# Altium schematic sheet Morpho_Arduino.SchDoc
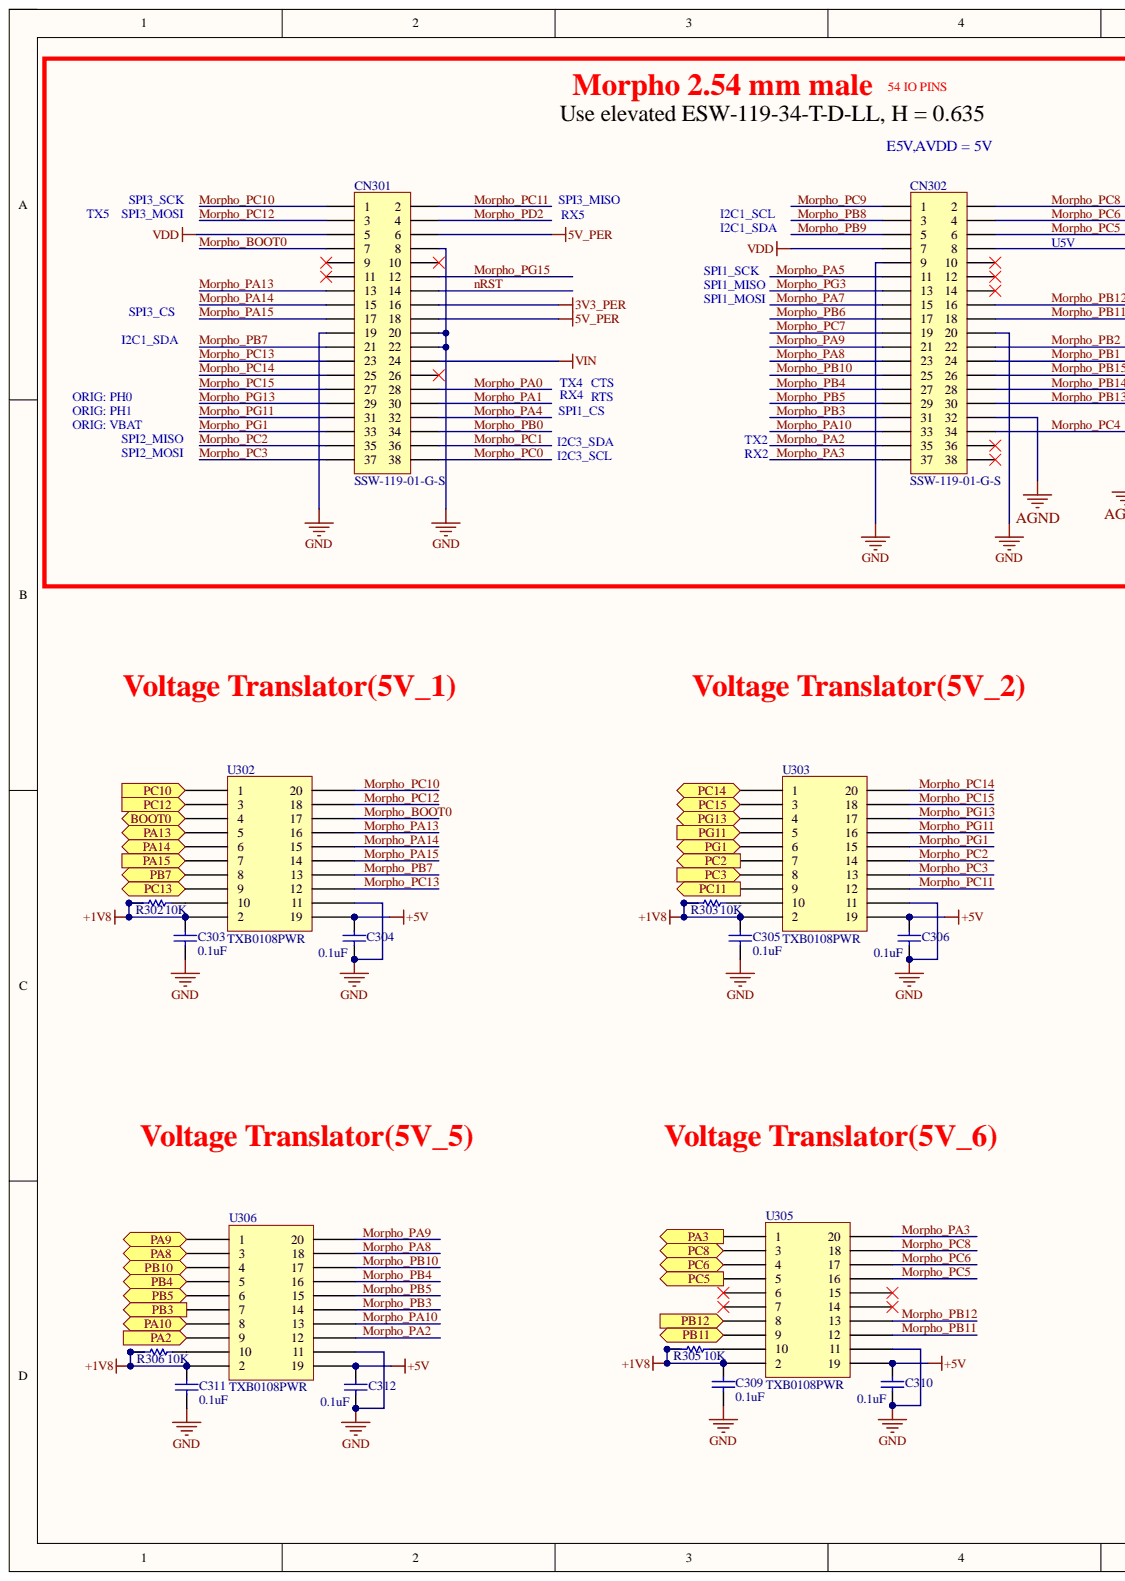
[diagram p0: Morpho_Arduino.SchDoc, left]
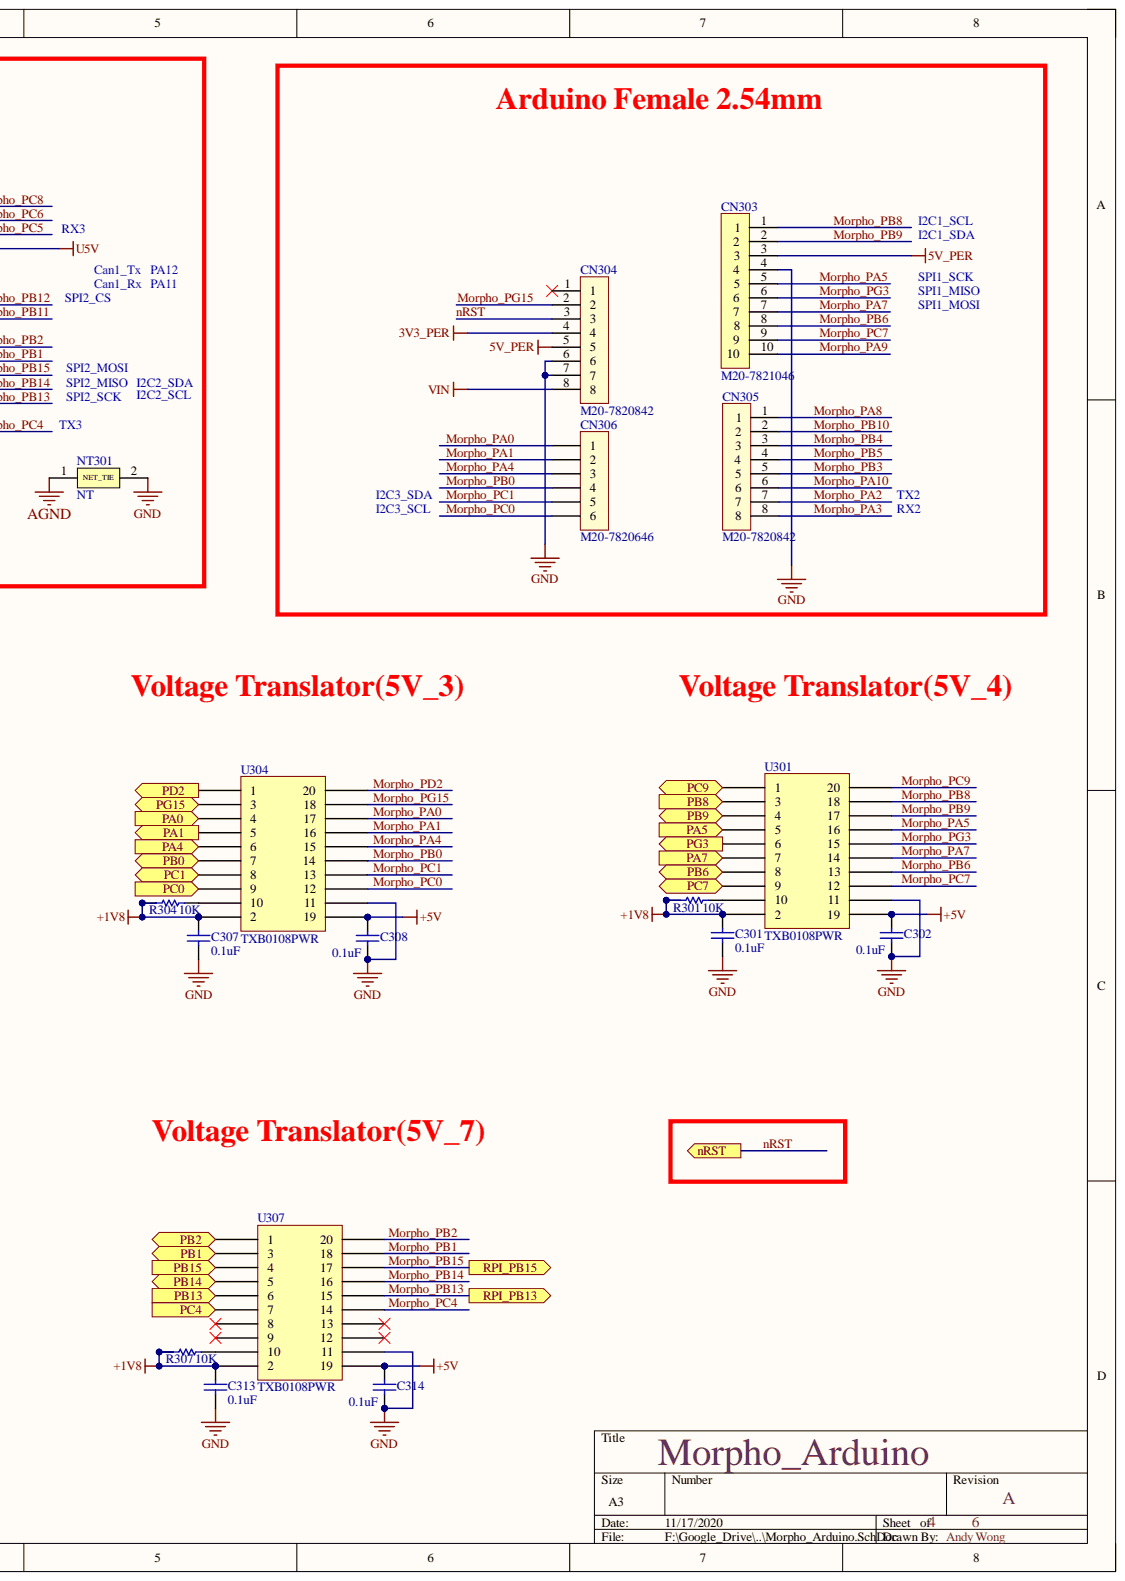
[diagram p1: Morpho_Arduino.SchDoc, right]

## Parts
C301  0.1uF  [Cap 0402]
C302  0.1uF  [Cap 0402]
C303  0.1uF  [Cap 0402]
C304  0.1uF  [Cap 0402]
C305  0.1uF  [Cap 0402]
C306  0.1uF  [Cap 0402]
C307  0.1uF  [Cap 0402]
C308  0.1uF  [Cap 0402]
C309  0.1uF  [Cap 0402]
C310  0.1uF  [Cap 0402]
C311  0.1uF  [Cap 0402]
C312  0.1uF  [Cap 0402]
C313  0.1uF  [Cap 0402]
C314  0.1uF  [Cap 0402]
CN301  SSW-119-01-G-S  [TSW-119-09-T-D]
CN302  SSW-119-01-G-S  [TSW-119-09-T-D]
CN303  M20-7821046  [M20-7821046]
CN304  M20-7820842  [M20-7820842]
CN305  M20-7820842  [M20-7820842]
CN306  M20-7820646  [M20-7820646]
NT301  NT  [NetTie]
R301  10K  [Res 0402]
R302  10K  [Res 0402]
R303  10K  [Res 0402]
R304  10K  [Res 0402]
R305  10K  [Res 0402]
R306  10K  [Res 0402]
R307  10K  [Res 0402]
U301  TXB0108PWR  [TXB0108PWR]
U302  TXB0108PWR  [TXB0108PWR]
U303  TXB0108PWR  [TXB0108PWR]
U304  TXB0108PWR  [TXB0108PWR]
U305  TXB0108PWR  [TXB0108PWR]
U306  TXB0108PWR  [TXB0108PWR]
U307  TXB0108PWR  [TXB0108PWR]

## Ports
BOOT0, nRST, PA0, PA1, PA2, PA3, PA4, PA5, PA7, PA8, PA9, PA10, PA13, PA14, PA15, PB0, PB1, PB2, PB3, PB4, PB5, PB6, PB7, PB8, PB9, PB10, PB11, PB12, PB13, PB14, PB15, PC0, PC1, PC2, PC3, PC4, PC5, PC6, PC7, PC8, PC9, PC10, PC11, PC12, PC13, PC14, PC15, PD2, PG1, PG3, PG11, PG13, PG15, RPI_PB13, RPI_PB15

## Nets
3V3_PER: CN301.16 CN304.4
5V_PER: C302.2 C304.2 C306.2 C308.2 C310.2 C312.2 C314.2 CN301.6 CN301.18 CN303.3 CN304.5 U301.19 U302.19 U303.19 U304.19 U305.19 U306.19 U307.19
+1V8: C301.1 C303.1 C305.1 C307.1 C309.1 C311.1 C313.1 R301.2 R302.2 R303.2 R304.2 R305.2 R306.2 R307.2 U301.2 U302.2 U303.2 U304.2 U305.2 U306.2 U307.2
AGND: CN302.32 NT301.1
GND: C301.2 C302.1 C303.2 C304.1 C305.2 C306.1 C307.2 C308.1 C309.2 C310.1 C311.2 C312.1 C313.2 C314.1 CN301.8 CN301.19 CN301.20 CN301.22 CN302.9 CN302.20 CN303.4 CN304.6 CN304.7 NT301.2 U301.11 U302.11 U303.11 U304.11 U305.11 U306.11 U307.11
U5V: CN302.8
VDD: CN301.5 CN302.7
VIN: CN301.24 CN304.8
BOOT0: U302.4 ; port BOOT0
Morpho_BOOT0: CN301.7 U302.17
Morpho_PA0: CN301.28 CN306.1 U304.17
Morpho_PA1: CN301.30 CN306.2 U304.16
Morpho_PA2: CN302.35 CN305.7 U306.12
Morpho_PA3: CN302.37 CN305.8 U305.20
Morpho_PA4: CN301.32 CN306.3 U304.15
Morpho_PA5: CN302.11 CN303.5 U301.16
Morpho_PA7: CN302.15 CN303.7 U301.14
Morpho_PA8: CN302.23 CN305.1 U306.18
Morpho_PA9: CN302.21 CN303.10 U306.20
Morpho_PA10: CN302.33 CN305.6 U306.13
Morpho_PA13: CN301.13 U302.16
Morpho_PA14: CN301.15 U302.15
Morpho_PA15: CN301.17 U302.14
Morpho_PB0: CN301.34 CN306.4 U304.14
Morpho_PB1: CN302.24 U307.18
Morpho_PB2: CN302.22 U307.20
Morpho_PB3: CN302.31 CN305.5 U306.14
Morpho_PB4: CN302.27 CN305.3 U306.16
Morpho_PB5: CN302.29 CN305.4 U306.15
Morpho_PB6: CN302.17 CN303.8 U301.13
Morpho_PB7: CN301.21 U302.13
Morpho_PB8: CN302.3 CN303.1 U301.18
Morpho_PB9: CN302.5 CN303.2 U301.17
Morpho_PB10: CN302.25 CN305.2 U306.17
Morpho_PB11: CN302.18 U305.12
Morpho_PB12: CN302.16 U305.13
Morpho_PB13: CN302.30 U307.15 ; port RPI_PB13
Morpho_PB14: CN302.28 U307.16
Morpho_PB15: CN302.26 U307.17 ; port RPI_PB15
Morpho_PC0: CN301.38 CN306.6 U304.12
Morpho_PC1: CN301.36 CN306.5 U304.13
Morpho_PC2: CN301.35 U303.14
Morpho_PC3: CN301.37 U303.13
Morpho_PC4: CN302.34 U307.14
Morpho_PC5: CN302.6 U305.16
Morpho_PC6: CN302.4 U305.17
Morpho_PC7: CN302.19 CN303.9 U301.12
Morpho_PC8: CN302.2 U305.18
Morpho_PC9: CN302.1 U301.20
Morpho_PC10: CN301.1 U302.20
Morpho_PC11: CN301.2 U303.12
Morpho_PC12: CN301.3 U302.18
Morpho_PC13: CN301.23 U302.12
Morpho_PC14: CN301.25 U303.20
Morpho_PC15: CN301.27 U303.18
Morpho_PD2: CN301.4 U304.20
Morpho_PG1: CN301.33 U303.15
Morpho_PG3: CN302.13 CN303.6 U301.15
Morpho_PG11: CN301.31 U303.16
Morpho_PG13: CN301.29 U303.17
Morpho_PG15: CN301.12 CN304.2 U304.18
nRST: CN301.14 CN304.3 ; port nRST
PA0: U304.4 ; port PA0
PA1: U304.5 ; port PA1
PA2: U306.9 ; port PA2
PA3: U305.1 ; port PA3
PA4: U304.6 ; port PA4
PA5: U301.5 ; port PA5
PA7: U301.7 ; port PA7
PA8: U306.3 ; port PA8
PA9: U306.1 ; port PA9
PA10: U306.8 ; port PA10
PA13: U302.5 ; port PA13
PA14: U302.6 ; port PA14
PA15: U302.7 ; port PA15
PB0: U304.7 ; port PB0
PB1: U307.3 ; port PB1
PB2: U307.1 ; port PB2
PB3: U306.7 ; port PB3
PB4: U306.5 ; port PB4
PB5: U306.6 ; port PB5
PB6: U301.8 ; port PB6
PB7: U302.8 ; port PB7
PB8: U301.3 ; port PB8
PB9: U301.4 ; port PB9
PB10: U306.4 ; port PB10
PB11: U305.9 ; port PB11
PB12: U305.8 ; port PB12
PB13: U307.6 ; port PB13
PB14: U307.5 ; port PB14
PB15: U307.4 ; port PB15
PC0: U304.9 ; port PC0
PC1: U304.8 ; port PC1
PC2: U303.7 ; port PC2
PC3: U303.8 ; port PC3
PC4: U307.7 ; port PC4
PC5: U305.5 ; port PC5
PC6: U305.4 ; port PC6
PC7: U301.9 ; port PC7
PC8: U305.3 ; port PC8
PC9: U301.1 ; port PC9
PC10: U302.1 ; port PC10
PC11: U303.9 ; port PC11
PC12: U302.3 ; port PC12
PC13: U302.9 ; port PC13
PC14: U303.1 ; port PC14
PC15: U303.3 ; port PC15
PD2: U304.1 ; port PD2
PG1: U303.6 ; port PG1
PG3: U301.6 ; port PG3
PG11: U303.5 ; port PG11
PG13: U303.4 ; port PG13
PG15: U304.3 ; port PG15
NetR301_1: R301.1 U301.10
NetR302_1: R302.1 U302.10
NetR303_1: R303.1 U303.10
NetR304_1: R304.1 U304.10
NetR305_1: R305.1 U305.10
NetR306_1: R306.1 U306.10
NetR307_1: R307.1 U307.10

Not connected: CN301 9 10 11 26; CN302 10 12 14 36 38; CN304 1; U305 6 7 14 15; U307 8 9 12 13

## Library data of the parts
C301-C314 = Cap: GRM155R71C104KA88, 0402; Component Kind: Standard; LatestRevisionDate: 17-Jul-2002; LatestRevisionNote: Re-released for DXP Platform.; Library Name: Miscellaneous Devices.IntLib; PackageDescription: Radial Cap, Thru-Hole; 2 Leads; 0.3 in Pin Spacing; PackageReference: RAD-0.3; Published: 8-Jun-2000; Signal Integrity: Cap; Simulation: CAP; Publisher: Altium Limited
CN301 CN302 = Morpho: Morpho male Connecters; Component Kind: Standard; Library Name: MA_Eva_Board.SchLib
CN303 = M20-7821046: 10 Position Receptacle Connector Through Hole; Component Kind: Standard; Library Name: MA_Eva_Board.SchLib
CN304 CN305 = M20-7820842: 8 Position Receptacle Connector Through Hole; Component Kind: Standard; Library Name: MA_Eva_Board.SchLib
CN306 = M20-7820646: 6 Position Receptacle Connector Through Hole; Component Kind: Standard; Library Name: MA_Eva_Board.SchLib
NT301 = Nettie: Net tie; Component Kind: Net Tie; Library Name: MA_Eva_Board.SchLib
R301-R307 = Res1: ERA-2AED103X, 0402; Component Kind: Standard; LatestRevisionDate: 17-Jul-2002; LatestRevisionNote: Re-released for DXP Platform.; Library Name: Miscellaneous Devices.IntLib; PackageDescription: Axial Device, Thru-Hole; 2 Leads; 0.3 in Pin Spacing; PackageReference: AXIAL-0.3; Published: 8-Jun-2000; Signal Integrity: Res; Simulation: RESISTOR; Publisher: Altium Limited
U301-U307 = TXB0108PWR: 8-Bit Bidirectional Voltage-Level Translator w; Component Kind: Standard; Library Name: MA_Eva_Board.SchLib
